# Altium schematic sheet Connector.SchDoc(Connector)
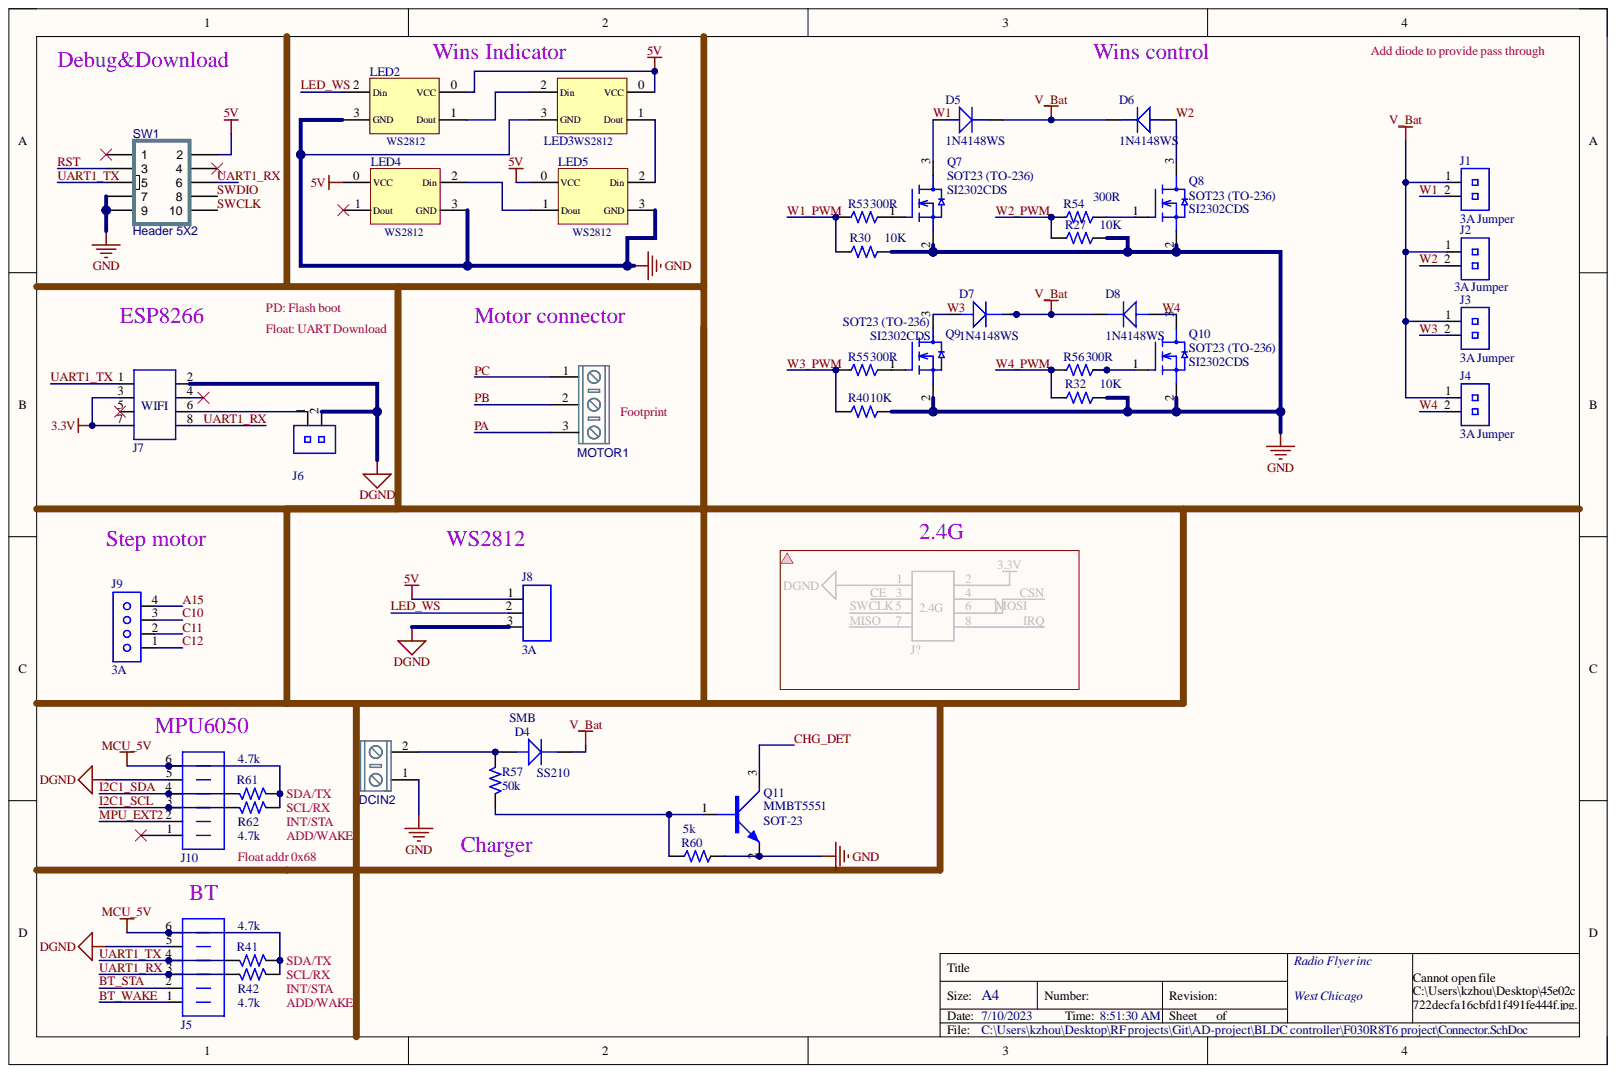
[diagram p0: Connector.SchDoc]

## Parts
D4  RCD102100-0110  [SMB]  (value not drawn)
D5  RCD114148-0020  [SOD-323]  (value not drawn)
D6  RCD114148-0020  [SOD-323]  (value not drawn)
D7  RCD114148-0020  [SOD-323]  (value not drawn)
D8  RCD114148-0020  [SOD-323]  (value not drawn)
DCIN2  KF/WJ/DG 30x connecter  [KF/WJ/DG 301-2p-5.0mm]  (value not drawn)
J1  Current: 3A Jumper  [HOUSING 2P PH=2.54mm Jumper]  (hidden comment: CCH254020-0102)
J2  Current: 3A Jumper  [HOUSING 2P PH=2.54mm Jumper]  (hidden comment: CCH254020-0102)
J3  Current: 3A Jumper  [HOUSING 2P PH=2.54mm Jumper]  (hidden comment: CCH254020-0102)
J4  Current: 3A Jumper  [HOUSING 2P PH=2.54mm Jumper]  (hidden comment: CCH254020-0102)
J5  T690300121600  [CONN 6P PH=2.5mm RA XHB-6AW-KL]  (value not drawn)
J6  CCH254020-0102  [HOUSING 2P PH=2.54mm Jumper]  (value not drawn)
J7  WIFI  [HEAD 8P 2X4 PH=2.54mm 90 H5mm_2.54-2X4AW-10.4]
J8  Current: 3A  [HEAD 3P PH=2.54mm 180]  (hidden comment: CCP254010-0103)
J9  Current: 3A  [HEAD 4P PH=2.54mm 180]  (hidden comment: CCP254260-0104)
J10  T690300121600  [CONN 6P PH=2.5mm RA XHB-6AW-KL]  (value not drawn)
LED2  WS2812  [WS2812]
LED3  WS2812  [WS2812]
LED4  WS2812  [WS2812]
LED5  WS2812  [WS2812]
MOTOR1  KF/WJ/DG 30x connecter  [KF/WJ/DG 301-3p-5.0mm]  (value not drawn)
Q7  SI2302CDS  [SOT23-132-RW]
Q8  SI2302CDS  [SOT23-132-RW]
Q9  SI2302CDS  Package: SOT23 (TO-236)  [SOT23-132-RW]
Q10  SI2302CDS  [SOT23-132-RW]
Q11  Package: SOT-23  [SOT23-132-RW]  (hidden comment: RCN005551-0001)
R27  value 10K  [0603-RW]  (hidden comment: T5024421083A9)
R30  value 10K  [0603-RW]  (hidden comment: T5024421083A9)
R32  value 10K  [0603-RW]  (hidden comment: T5024421083A9)
R40  value 10K  [0603-RW]  (hidden comment: T5024421083A9)
R41  value 4.7k  [0603-RW]  (hidden comment: T5024421083A9)
R42  value 4.7k  [0603-RW]  (hidden comment: T5024421083A9)
R53  value 300R  [0603-RW]  (hidden comment: T5024421083A9)
R54  value 300R  [0603-RW]  (hidden comment: T5024421083A9)
R55  value 300R  [0603-RW]  (hidden comment: T5024421083A9)
R56  value 300R  [0603-RW]  (hidden comment: T5024421083A9)
R57  value 50k  [0603-RW]  (hidden comment: T5024421083A9)
R60  value 5k  [0603-RW]  (hidden comment: T5024421083A9)
R61  value 4.7k  [0603-RW]  (hidden comment: T5024421083A9)
R62  value 4.7k  [0603-RW]  (hidden comment: T5024421083A9)
SW1  Header 5X2  [HDR2X5]

## Nets
3.3V: J7.3 J7.7
5V: J8.1 LED2.0(VCC) LED3.0(VCC) LED4.0(VCC) LED5.0(VCC) SW1.2
DGND: J5.5 J6.2 J7.2 J8.3 J10.5
GND: DCIN2.1 LED2.3(GND) LED3.3(GND) LED4.3(GND) LED5.3(GND) Q7.2 Q8.2 Q9.2 Q10.2 Q11.2 R27.2 R30.2 R32.2 R40.2 R60.2 SW1.7 SW1.9
MCU_5V: J5.6 J10.6 R41.2 R42.2 R61.2 R62.2
V_Bat: D4.2 D5.2 D6.2 D7.2 D8.2 J1.1 J2.1 J3.1 J4.1
A15: J9.4
BT_STA: J5.2
BT_WAKE: J5.1
C10: J9.3
C11: J9.2
C12: J9.1
CHG_DET: Q11.3
I2C1_SCL: J10.3 R62.1
I2C1_SDA: J10.4 R61.1
LED_WS: J8.2 LED2.2(Din)
MPU_EXT2: J10.2
PA: MOTOR1.3
PB: MOTOR1.2
PC: MOTOR1.1
RST: SW1.3
SWCLK: SW1.10
SWDIO: SW1.8
UART1_RX: J5.3 J7.8 R42.1 SW1.6
UART1_TX: J5.4 J7.1 R41.1 SW1.5
W1: D5.1 J1.2 Q7.3
W1_PWM: R30.1 R53.1
W2: D6.1 J2.2 Q8.3
W2_PWM: R27.1 R54.1
W3: D7.1 J3.2 Q9.3
W3_PWM: R40.1 R55.1
W4: D8.1 J4.2 Q10.3
W4_PWM: R32.1 R56.1
NetD4_1: D4.1 DCIN2.2 R57.1
NetJ6_1: J6.1 J7.6
NetLED2_1: LED2.1(Dout) LED3.2(Din)
NetLED3_1: LED3.1(Dout) LED5.2(Din)
NetLED4_2: LED4.2(Din) LED5.1(Dout)
NetQ7_1: Q7.1 R53.2
NetQ8_1: Q8.1 R54.2
NetQ9_1: Q9.1 R55.2
NetQ10_1: Q10.1 R56.2
NetQ11_1: Q11.1 R57.2 R60.1

Not connected: J7 4 5; J10 1; LED4 1(Dout); SW1 1 4

## Library data of the parts
D4 = SS210: SWITC 100V 2A SS210 MIC G; DIP&SMD: SMD; Optional: USD (和572004962246 交易幣別不一樣)帋ISHIDDEN=T|IS|IS|IS|IS|IS|IS; SAP: T572005362246; Type: DIODE; Vendor Code: 10020343; Vendor Name: 深圳市春明电子有限公司; Component Kind: Standard; Current: 2A; Customer Specific: N/A; Library Name: R-Semiconductors.IntLib; MFG: MIC; MFG Part: SS210; Package: SMB; Voltage: 100V
D5-D8 = 1N4148WS: DIODE SW 1N4148 VF=0.7V SOD-323; Current: 150MA; DIP&SMD: SMD; MFG: TW SEMICONDUCTOR; SAP: T572005070208; Voltage: 100V; Component Kind: Standard; Customer Specific: N/A; Library Name: R-Semiconductors.IntLib; MFG Part: 1N4148WS; Package: SOD-323; Type: DIODE
DCIN2 = KF/WJ/DG 30x: 接线端子 2Pin; Component Kind: Standard; Library Name: 灵动SPIN360C 无刷驱动V1.6 集成封装库.IntLib
J1-J4 = HOUSING 2P PH=2.54mm Jumper: HOUSING SIL Jumper 2P 90059-1007 Molex; DIP&SMD: DIP; SAP: T690300000900; Value: 2.54mm; Vendor Code: 10020373; Vendor Name: 深圳市利民丰电子有限公司; Component Kind: Standard; Library Name: C-Connector.IntLib; Type: Connector
J5 J10 = CONN PH 6P PH=2.5mm RA XHB-6AW-KL: CONNECTOR 6PIN P=2.5 WHITE 90 K; CREATE_NAME: Nathan; DIP&SMD: DIP; MFG: 金佳电子; Value: 2.5mm; Component Kind: Standard; CREATE_TIME: 27-Sep-2017; Library Name: C-Connector.IntLib; MFG Part: XHB-6AW-KL; SAP: T690300121600; Type: Connector
J6 = HOUSING 2P PH=2.54mm Jumper: HOUSING SIL Jumper 2P 90059-1007 Molex; Current: 3A Jumper; DIP&SMD: DIP; SAP: T690300000900; Value: 2.54mm; Vendor Code: 10020373; Vendor Name: 深圳市利民丰电子有限公司; Component Kind: Standard; Library Name: C-Connector.IntLib; Type: Connector
J7 = HEAD 8P 2X4 PH=2.54mm 90 H5mm_2.54-2X4AW-10.4: 2X4P P:2.54MM 90° DIP K; CREATE_NAME: Nathan; MFG: JINJIA; SAP: T690300110000; Value: 2.54mm; Component Kind: Standard; CREATE_TIME: 12-Sep-2017; DIP&SMD: DIP; Library Name: C-Connector.IntLib; MFG Part: 2.54-2X4AW-10.4; Type: Connector
J8 = HEAD 3P PH=2.54mm 180: HEAD PIN 3P P2.54*H11.6MM; DIP&SMD: DIP; SAP: T690300031100; Value: 2.54mm; Vendor Code: 10020373; Vendor Name: 深圳市利民丰电子有限公司; Component Kind: Standard; Library Name: C-Connector.IntLib; Type: Connector
J9 = HEAD 4P PH=2.54mm 180 H11.5mm: HEAD PIN 4P P2.54xH11.5MM; DIP&SMD: DIP; SAP: T690300023200; Value: 2.54mm; Vendor Code: 10009989; Vendor Name: 亿源电子有限公司; Component Kind: Standard; Library Name: C-Connector.IntLib; Type: Connector
MOTOR1 = KF/WJ/DG 30x-3P: 接线端子 3Pin; Component Kind: Standard; Library Name: 灵动SPIN360C 无刷驱动V1.6 集成封装库.IntLib
Q7-Q10 = SI2302CDS: NMOS FET-Si2302CDS; CREATE_NAME: Nathan; DIP&SMD: SMD; MFG: VISHAY; Component Kind: Standard; CREATE_TIME: 17-Nov-2020; Customer Specific: N/A; Library Name: R-Semiconductors.IntLib; MFG Part: SI2302CDS; Type: NMOS
  Q7 Q8 Q10: Package: SOT23 (TO-236)
Q11 = MMBT5551: XISTR NPN MMBT5551 SOT-23 FAIRCHILD; DIP&SMD: SMD; MFG: 宣瑜; SAP: T581001790453; Vendor Code: 10020343; Vendor Name: 深圳市春明电子有限公司; Component Kind: Standard; Customer Specific: N/A; Library Name: R-Semiconductors.IntLib; MFG Part: MMBT5551; Type: NPN
R27 R30 R32 R40-R42 R53-R57 R60-R62 = RES0201 1/20W 1% 4.42K OHM_WALSIN: CHIP 4.42K OHM 200PPM +-1% 0201 WALS L; MFG Part: WR02X4421FAL; CREATE_NAME: Nathan; DIP&SMD: SMD; MFG: WALSIN (華新); Power: 1/20W; TOL: 1%; Component Kind: Standard; CREATE_TIME: 23-July-2019; Customer Specific: N/A; Library Name: Q-Resistors.IntLib; PACKAGE: 0603; SAP: T5024421083A9; Type: Resistor
SW1 = Header 5X2: Header, 5-Pin, Dual row; Component Kind: Standard; LatestRevisionDate: 17-Jul-2002; LatestRevisionNote: Re-released for DXP Platform.; Price(RMB): 0.00; Signal Integrity: Connector; Library Name: 灵动SPIN360C 无刷驱动V1.6 集成封装库.IntLib; Publisher: Altium Limited
LED2-LED5 = WS2812: Component Kind: Standard; Library Name: K-LED-FUSE.IntLib
